# Altium schematic sheet Audio.SchDoc
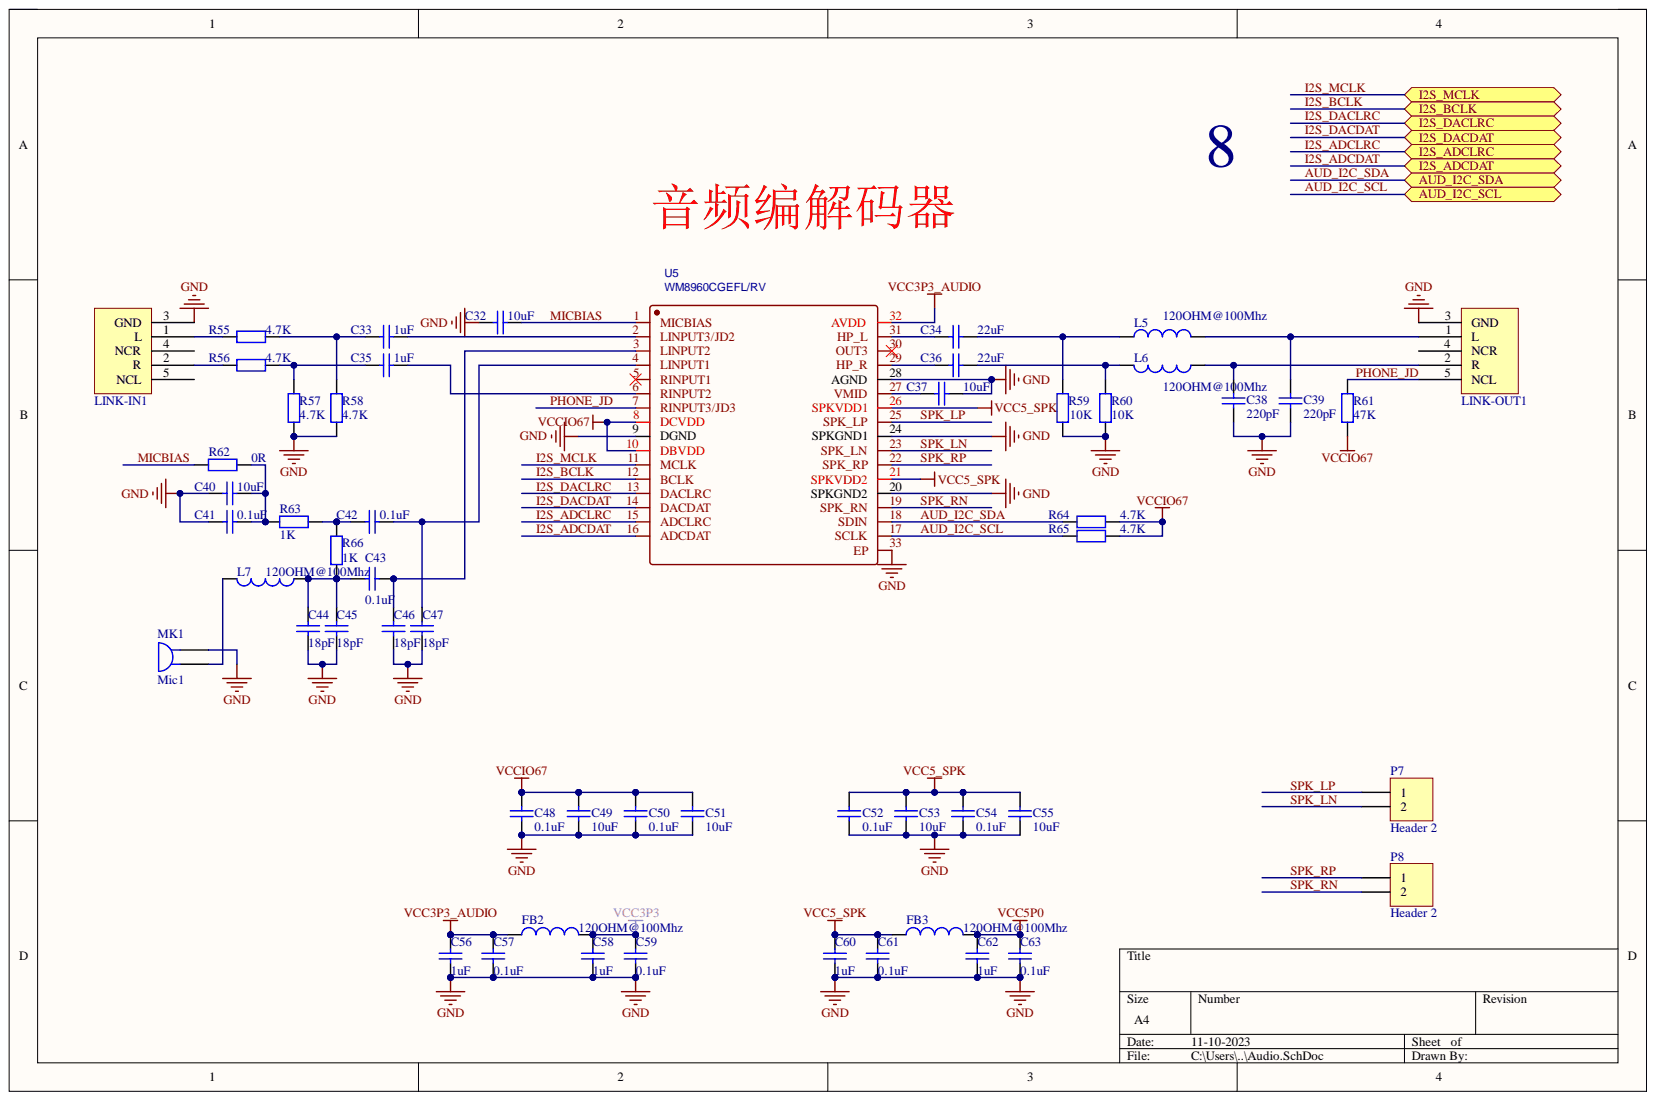
[diagram p0: Audio.SchDoc]

## Parts
C32  10uF  [C0805]
C33  1uF  [C0603]
C34  22uF  [C1206]
C35  1uF  [C0603]
C36  22uF  [C1206]
C37  10uF  [C0805]
C38  220pF  [C0402]
C39  220pF  [C0402]
C40  10uF  [C0805]
C41  0.1uF  [C0402]
C42  0.1uF  [C0402]
C43  0.1uF  [C0402]
C44  18pF  [C0402]
C45  18pF  [C0402]
C46  18pF  [C0402]
C47  18pF  [C0402]
C48  0.1uF  [C0402]
C49  10uF  [C0805]
C50  0.1uF  [C0402]
C51  10uF  [C0805]
C52  0.1uF  [C0402]
C53  10uF  [C0805]
C54  0.1uF  [C0402]
C55  10uF  [C0805]
C56  1uF  [C0603]
C57  0.1uF  [C0402]
C58  1uF  [C0603]
C59  0.1uF  [C0402]
C60  1uF  [C0603]
C61  0.1uF  [C0402]
C62  1uF  [C0603]
C63  0.1uF  [C0402]
FB2  120OHM@100Mhz  [L0603]
FB3  120OHM@100Mhz  [L0603]
L5  120OHM@100Mhz  [L0603]
L6  120OHM@100Mhz  [L0603]
L7  120OHM@100Mhz  [L0603]
LINK-IN1  LI  [PJ-315-Y]
LINK-OUT1  LI  [PJ-315-PK]
MK1  Mic1  [HDR1X2]
P7  Header 2  [HDR1X2]
P8  Header 2  [HDR1X2]
R55  4.7K  [R0402]
R56  4.7K  [R0402]
R57  4.7K  [R0402]
R58  4.7K  [R0402]
R59  10K  [R0402]
R60  10K  [R0402]
R61  47K  [C0402]
R62  0R  [R0402]
R63  1K  [R0402]
R64  4.7K  [R0402]
R65  4.7K  [R0402]
R66  1K  [R0402]
U5  WM8960CGEFL/RV  [qfn-32_l5_0-w5_0-p0_50-tl-ep]

## Ports
AUD_I2C_SCL, AUD_I2C_SDA, I2S_ADCDAT, I2S_ADCLRC, I2S_BCLK, I2S_DACDAT, I2S_DACLRC, I2S_MCLK

## Nets
GND: C32.2 C37.2 C38.1 C39.1 C40.1 C41.1 C44.1 C45.1 C46.1 C47.1 C48.2 C49.2 C50.2 C51.2 C52.2 C53.2 C54.2 C55.2 C56.1 C57.1 C58.1 C59.1 C60.1 C61.1 C62.1 C63.1 LINK-IN1.3(GND) LINK-OUT1.3(GND) MK1.2 R57.1 R58.1 R59.2 R60.2 U5.9(DGND) U5.20(SPKGND2) U5.24(SPKGND1) U5.28(AGND) U5.33(EP)
VCC3P3: C58.2 C59.2 FB2.2
VCC3P3_AUDIO: C56.2 C57.2 FB2.1 U5.32(AVDD)
VCC5_SPK: C52.1 C53.1 C54.1 C55.1 C60.2 C61.2 FB3.1 U5.21(SPKVDD2) U5.26(SPKVDD1)
VCC5P0: C62.2 C63.2 FB3.2
VCCIO67: C48.1 C49.1 C50.1 C51.1 R61.2 R64.1 R65.1 U5.8(DCVDD) U5.10(DBVDD)
AUD_I2C_SCL: R65.2 U5.17(SCLK) ; port AUD_I2C_SCL
AUD_I2C_SDA: R64.2 U5.18(SDIN) ; port AUD_I2C_SDA
I2S_ADCDAT: U5.16(ADCDAT) ; port I2S_ADCDAT
I2S_ADCLRC: U5.15(ADCLRC) ; port I2S_ADCLRC
I2S_BCLK: U5.12(BCLK) ; port I2S_BCLK
I2S_DACDAT: U5.14(DACDAT) ; port I2S_DACDAT
I2S_DACLRC: U5.13(DACLRC) ; port I2S_DACLRC
I2S_MCLK: U5.11(MCLK) ; port I2S_MCLK
MICBIAS: C32.1 R62.2 U5.1(MICBIAS)
PHONE_JD: LINK-OUT1.5(NCL) R61.1 U5.7(RINPUT3/JD3)
SPK_LN: P7.2 U5.23(SPK_LN)
SPK_LP: P7.1 U5.25(SPK_LP)
SPK_RN: P8.2 U5.19(SPK_RN)
SPK_RP: P8.1 U5.22(SPK_RP)
NetC33_1: C33.1 R55.2 R58.2
NetC33_2: C33.2 U5.2(LINPUT3/JD2)
NetC34_1: C34.1 U5.31(HP_L)
NetC34_2: C34.2 L5.1 R59.1
NetC35_1: C35.1 R56.2 R57.2
NetC35_2: C35.2 U5.6(RINPUT2)
NetC36_1: C36.1 U5.29(HP_R)
NetC36_2: C36.2 L6.2 R60.1
NetC37_1: C37.1 U5.27(VMID)
NetC38_2: C38.2 L6.1 LINK-OUT1.2(R)
NetC39_2: C39.2 L5.2 LINK-OUT1.1(L)
NetC40_2: C40.2 C41.2 R62.1 R63.2
NetC42_1: C42.1 R63.1 R66.2
NetC42_2: C42.2 C47.2 U5.4(LINPUT1)
NetC43_1: C43.1 C44.2 C45.2 L7.1 R66.1
NetC43_2: C43.2 C46.2 U5.3(LINPUT2)
NetL7_2: L7.2 MK1.1
NetLINK-IN1_1: LINK-IN1.1(L) R55.1
NetLINK-IN1_2: LINK-IN1.2(R) R56.1

Not connected: LINK-IN1 4(NCR) 5(NCL); LINK-OUT1 4(NCR); U5 5(RINPUT1) 30(OUT3)

## Library data of the parts
C32-C55 = Cap: Capacitor; Component Kind: Standard; LatestRevisionDate: 17-Jul-2002; LatestRevisionNote: Re-released for DXP Platform.; Library Name: Miscellaneous Devices.IntLib; PackageDescription: Radial Cap, Thru-Hole; 2 Leads; 0.3 in Pin Spacing; PackageReference: RAD-0.3; Published: 8-Jun-2000; Signal Integrity: Cap; Simulation: CAP; Publisher: Altium Limited
  C32 C37 C40 C49 C51 C53 C55: Value: 10uF
  C33 C35: Value: 1uF
  C34 C36: Value: 22uF
  C38 C39: Value: 220pF
  C41-C43 C48 C50 C52 C54: Value: 0.1uF
  C44-C47: Value: 18pF
C56-C63 = Cap Semi: Capacitor (Semiconductor SIM Model); Component Kind: Standard; LatestRevisionDate: 29-May-2009; LatestRevisionNote: IPC-7351 Footprint Added.; Library Name: NEW.SchLib; PackageDescription: Chip Capacitor; PackageReference: C1206; Published: 8-Jun-2000; Publisher: Altium Limited
  C56 C58 C60 C62: Value: 1uF
  C57 C59 C61 C63: Value: 0.1uF
FB2 FB3 L5-L7 = L: Inductor; Component Kind: Standard; Library Name: plm60x_v001.SchLib
LINK-IN1 = LINK-IN: Component Kind: Standard; Description: LINK-IN; Library Name: ACZ702-V2.0.SchLib
LINK-OUT1 = LINK-OUT: Component Kind: Standard; Description: LINK-OUT; Library Name: ACZ702-V2.0.SchLib
MK1 = Mic1: Microphone; Component Kind: Standard; LatestRevisionDate: 17-Jul-2002; LatestRevisionNote: Re-released for DXP Platform.; Library Name: Miscellaneous Devices.IntLib; PackageReference: PIN2; Published: 24-Mar-1999; Publisher: Altium Limited
P7 P8 = Header 2: Header, 2-Pin; Component Kind: Standard; LatestRevisionDate: 17-Jul-2002; LatestRevisionNote: Re-released for DXP Platform.; Library Name: Miscellaneous Connectors.IntLib; Signal Integrity: Connector; Publisher: Altium Limited
R55-R66 = Res2: Resistor; Component Kind: Standard; LatestRevisionDate: 17-Jul-2002; LatestRevisionNote: Re-released for DXP Platform.; PackageReference: AXIAL-0.4; Published: 8-Jun-2000; Library Name: 元件封装库.pcblib; Publisher: Altium Limited
  R55-R58 R64 R65: Value: 4.7K
  R59 R60: Value: 10K
  R61: Value: 47K
  R62: Value: 0R
  R63 R66: Value: 1K
U5 = WM8960CGEFL/RV: BOM_Manufacturer: WOLFSON; SMT Type: Extend; BOM_LCSC Assembly: Yes; BOM_Manufacturer Part: WM8960CGEFL/RV; BOM_Supplier: LCSC; BOM_Supplier Part: C18752; Component Kind: Standard; image: //image.lceda.cn/szlcsc/C18752.jpg; spicePre: U; spiceSymbolName: WM8960CGEFL/RV
